# Altium schematic sheet MAIN.SchDoc
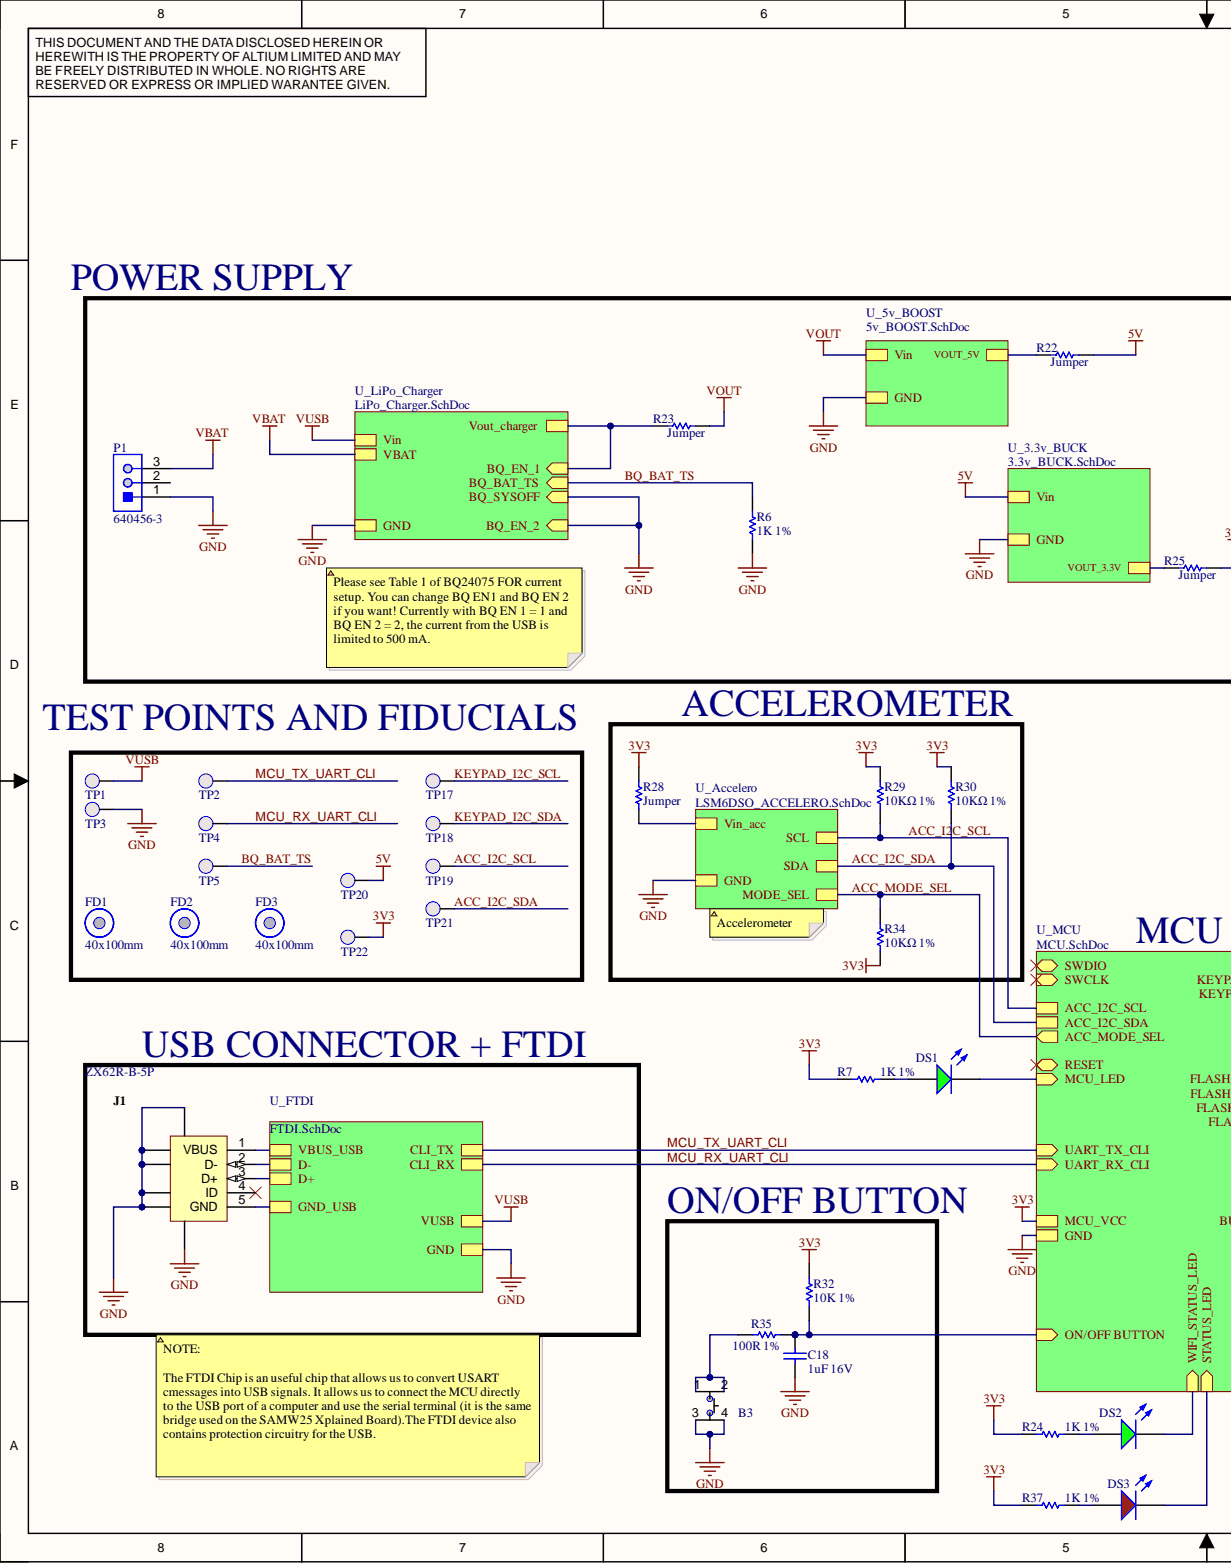
[diagram p0: MAIN.SchDoc, left]
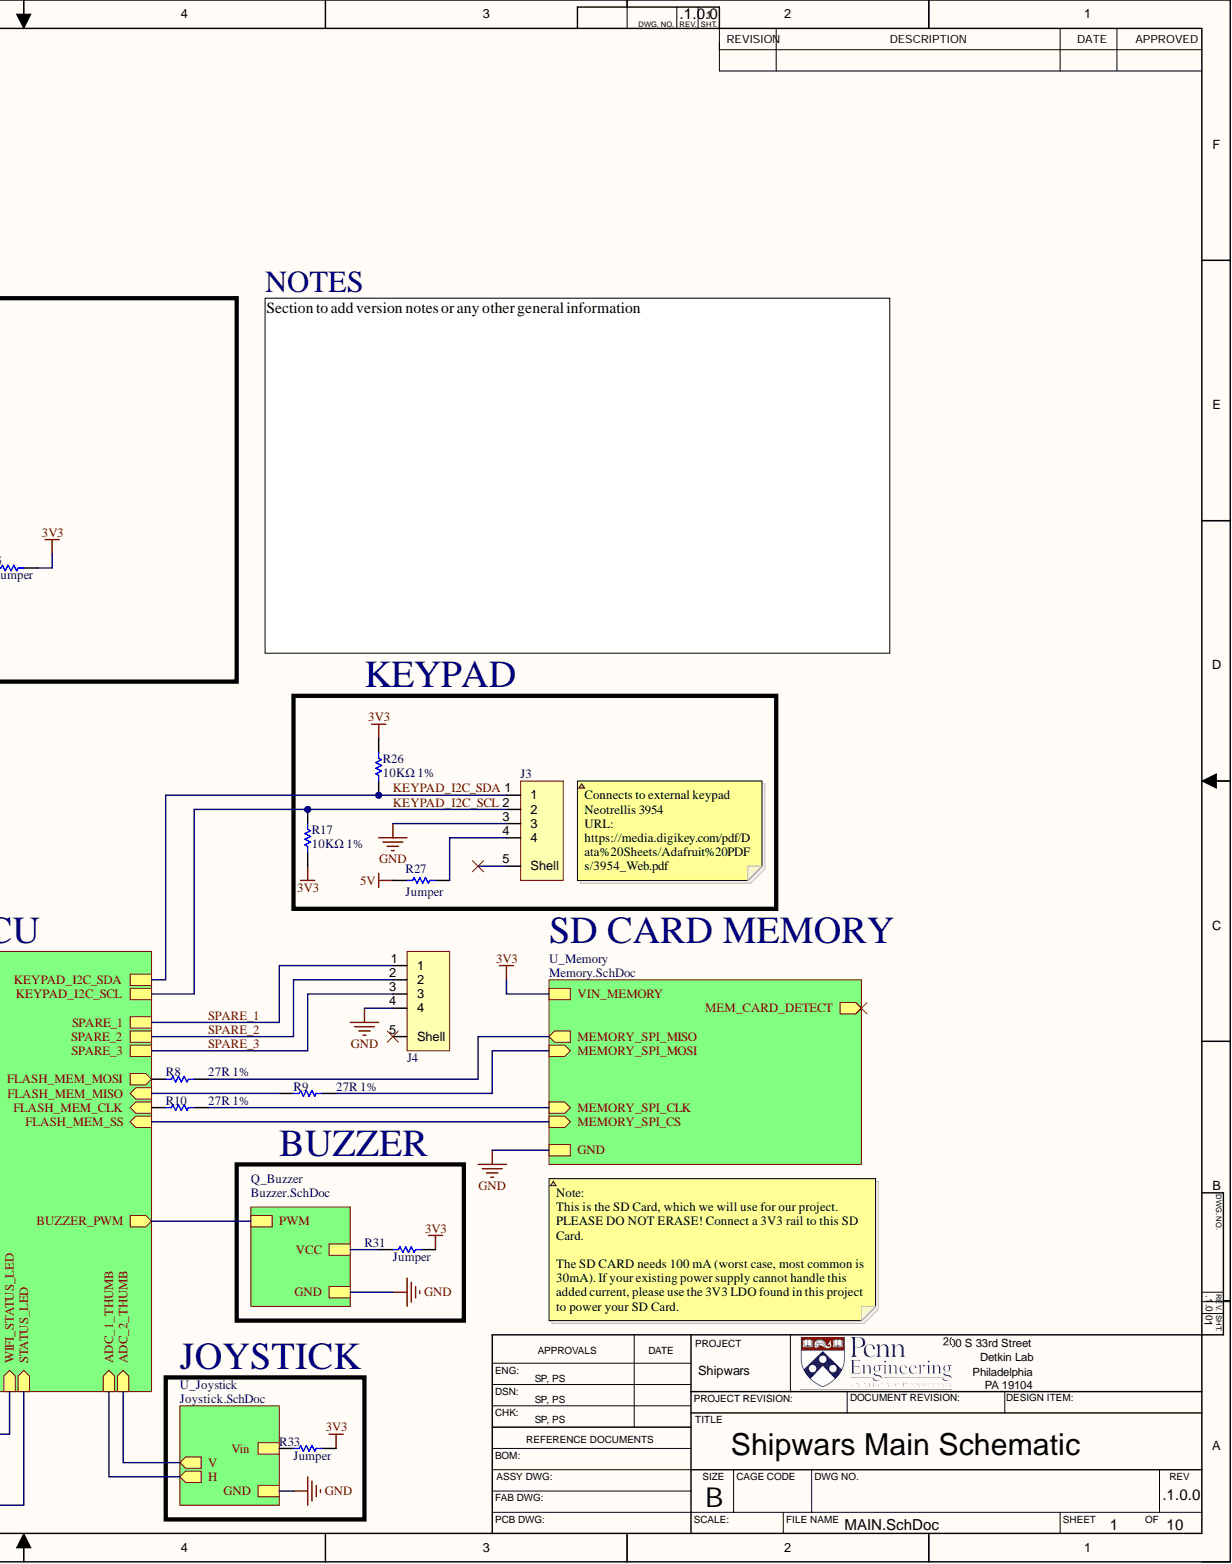
[diagram p1: MAIN.SchDoc, right]

## Parts
B3  PTS810 SJK 250 SMTR LFS  [PTS810 SJK 250 SMTR LFS]  (value not drawn)
C18  1uF 16V  value 1uF  [CAPC0603(1608)100_N]
DS1  LTST-C170GKT  [LTST-C170GKT_V]  (value not drawn)
DS2  LTST-C170GKT  [LTST-C170GKT_V]  (value not drawn)
DS3  LTST-C170CKT  [LTST-C170CKT_V]  (value not drawn)
FD1  40x100mm  [Fiducial_FT]  (no BOM)
FD2  40x100mm  [Fiducial_FT]  (no BOM)
FD3  40x100mm  [Fiducial_FT]  (no BOM)
J1  ZX62R-B-5P  [HIRO-ZX62R-B-5P_V]
J3  S4B-PH-SM4-TB(LF)(SN)  [S4B-PH-SM4-TB(LF)(SN)]  (value not drawn)
J4  S4B-PH-SM4-TB(LF)(SN)  [S4B-PH-SM4-TB(LF)(SN)]  (value not drawn)
P1  640456-3  [TECO-640456-3]
R6  1K 1%  [RESC0603(1608)_N]
R7  1K 1%  [RESC0603(1608)_N]
R8  27R 1%  [RESC0603(1608)_N]
R9  27R 1%  [RESC0603(1608)_N]
R10  27R 1%  [RESC0603(1608)_N]
R17  10KΩ 1%  [RESC0603(1608)_N]
R22  Jumper  [RESC0603(1608)_N]
R23  Jumper  [RESC0603(1608)_N]
R24  1K 1%  [RESC0603(1608)_N]
R25  Jumper  [RESC0603(1608)_N]
R26  10KΩ 1%  [RESC0603(1608)_N]
R27  Jumper  [RESC0603(1608)_N]
R28  Jumper  [RESC0603(1608)_N]
R29  10KΩ 1%  [RESC0603(1608)_N]
R30  10KΩ 1%  [RESC0603(1608)_N]
R31  Jumper  [RESC0603(1608)_N]
R32  10K 1%  [RESC0603(1608)_N]
R33  Jumper  [RESC0603(1608)_N]
R34  10KΩ 1%  [RESC0603(1608)_N]
R35  100R 1%  [RESC0603(1608)_N]
R37  1K 1%  [RESC0603(1608)_N]
TP1  0.1" Pad  [PCBComponent]  (value not drawn)  (no BOM)
TP2  0.1" Pad  [PCBComponent]  (value not drawn)  (no BOM)
TP3  0.1" Pad  [PCBComponent]  (value not drawn)  (no BOM)
TP4  0.1" Pad  [PCBComponent]  (value not drawn)  (no BOM)
TP5  0.1" Pad  [PCBComponent]  (value not drawn)  (no BOM)
TP17  0.1" Pad  [PCBComponent]  (value not drawn)  (no BOM)
TP18  0.1" Pad  [PCBComponent]  (value not drawn)  (no BOM)
TP19  0.1" Pad  [PCBComponent]  (value not drawn)  (no BOM)
TP20  0.1" Pad  [PCBComponent]  (value not drawn)  (no BOM)
TP21  0.1" Pad  [PCBComponent]  (value not drawn)  (no BOM)
TP22  0.1" Pad  [PCBComponent]  (value not drawn)  (no BOM)

## Nets
3V3: R7.1 R17.2 R24.1 R25.2 R26.2 R28.2 R29.2 R30.2 R31.2 R32.2 R33.2 R34.1 R37.1 TP22.1
5V: R22.2 R27.2 TP20.1
GND: B3.3 B3.4 C18.1 J1.6 J1.7 J1.8 J1.9 J1.10 J1.11 J3.3 J4.4 P1.1 R6.2 TP3.1
VBAT: P1.3
VOUT: R23.2
VUSB: TP1.1
ACC_I2C_SCL: R29.1 TP19.1
ACC_I2C_SDA: R30.1 TP21.1
ACC_MODE_SEL: R34.2
BQ_BAT_TS: R6.1 TP5.1
KEYPAD_I2C_SCL: J3.2 R17.1 TP17.1
KEYPAD_I2C_SDA: J3.1 R26.1 TP18.1
MCU_RX_UART_CLI: TP4.1
MCU_TX_UART_CLI: TP2.1
SPARE_1: J4.1
SPARE_2: J4.2
SPARE_3: J4.3
NetB3_1: B3.1 B3.2 R35.1
NetC18_2: C18.2 R32.1 R35.2
NetDS1_1: DS1.1 R7.2
NetDS1_2: DS1.2
NetDS2_1: DS2.1 R24.2
NetDS2_2: DS2.2
NetDS3_1: DS3.1 R37.2
NetDS3_2: DS3.2
NetJ1_1: J1.1(VBUS)
NetJ1_2: J1.2(D-)
NetJ1_3: J1.3(D+)
NetJ1_4: J1.4(ID)
NetJ1_5: J1.5(GND)
NetJ3_4: J3.4 R27.1
NetJ3_5: J3.5(Shell)
NetJ4_5: J4.5(Shell)
NetP1_2: P1.2
NetR8_1: R8.1
NetR8_2: R8.2
NetR9_1: R9.1
NetR9_2: R9.2
NetR10_1: R10.1
NetR10_2: R10.2
NetR22_1: R22.1
NetR23_1: R23.1
NetR25_1: R25.1
NetR28_1: R28.1
NetR31_1: R31.1
NetR33_1: R33.1
N00001:
N00002:
N00003:
N00004:
N00005:
N00006:
N00007:
N00008:

## Library data of the parts
B3 = PTS810 SJK 250 SMTR LFS: SWITCH TACTILE SPST-NO 0.05A 16V Tactile Switch SPST-NO Top Actuated Surface Mount; Manufacturer: ITT /  C&K Components; Part # Aliases: Y97LT25B5DBFP; Actuator: Oval; Brand: C&K Components; Contact Form: SPST; Current Rating: 50 mA; Mounting Direction: Straight; Operating Force: 2.6 N; Stem Height: 2.5 mm; Switch Function: OFF - (ON); Travel: 0.15 mm; Voltage Rating DC: 16 VDC; Color: White; Component Kind: Standard; ComponentLink1Description: https://datasheet.ciiva.com/18514/pts810-4mar13-18514061.pdf; ComponentLink1URL: https://datasheet.ciiva.com/18514/pts810-4mar13-18514061.pdf; ComponentLink2Description: https://datasheet.ciiva.com/18514/pts810-4mar13-18514062.pdf; ComponentLink2URL: https://datasheet.ciiva.com/18514/pts810-4mar13-18514062.pdf; ComponentLink3Description: https://datasheet.ciiva.com/18514/pts810-4mar13-18514063.pdf; ComponentLink3URL: https://datasheet.ciiva.com/18514/pts810-4mar13-18514063.pdf; Contact Plating: Silver; Factory Pack Quantity: 2900; Library Name: Schematics.SchLib; Manufacturer Part Number: PTS810SJK250SMTRLFS; Mounting Style: SMD/SMT; Operating Temperature Range: - 40 C to + 85 C; Packaging: Reel; Product Category: Tactile Switches; RoHS: Details; Series: PTS810; Supplier 1: Digi-Key; Supplier Part Number 1: CKN10503CT-ND; Termination Style: J Form Lead
C18 = CMP-1035-02736-1: CAP 1uF 16V ±5% 0603 (1608 Metric) Thickness 1mm SMD; Case-EIA: 0603; Case-Metric: 1608; Tolerance: Â±5%; Component Kind: Standard; Library Name: Altium Content Vault; Max Thickness: 1 mm; Rated Voltage: 16 V; Technology: SMT
DS1 DS2 = CMP-1674-00002-5: LED Uni-Color Green, 100 mW, 120 mA, -55 to 85 degC, 2-Pin SMD, RoHS, Tape and Reel; Manufacturer: Lite-On; Colour: Green; Component Kind: Standard; ComponentLink1Description: Manufacturer URL; ComponentLink1URL: http://www.us.liteon.com/; ComponentLink2Description: Datasheet; ComponentLink2URL: http://media.digikey.com/pdf/Data%20Sheets/Lite-On%20PDFs/LTST-C170GKT.pdf; DatasheetVersion: 03/2003; Height: 1.1 mm; Length: 2 mm; Library Name: Altium Content Vault; Max Operating Temperature: 85 degC; Min Operating Temperature: -55 degC; Mount: Surface Mount; Mounting Technology: Surface Mount; Package Quantity: 1; PackageDescription: 2-Pin Surface Mount Device, Body 2 x 1.25 mm; PackageReference: C170GKT; Packaging: Tape and Reel; Pins: 2; RoHSCompliant: true
DS3 = CMP-1674-00001-5: LED Uni-Color Red, 100 mW, 200 mA, -55 to 85 degC, 2-Pin SMD, RoHS, Tape and Reel; Manufacturer: Lite-On; Colour: Red; Component Kind: Standard; ComponentLink1Description: Manufacturer URL; ComponentLink1URL: http://www.us.liteon.com/; ComponentLink2Description: Datasheet; ComponentLink2URL: http://media.digikey.com/pdf/Data%20Sheets/Lite-On%20PDFs/LTST-C170CKT.pdf; DatasheetVersion: 03/2003; Height: 1.1 mm; Length: 2 mm; Library Name: Altium Content Vault; Max Operating Temperature: 85 degC; Min Operating Temperature: -55 degC; Mount: Surface Mount; Mounting Technology: Surface Mount; Package Quantity: 3000; PackageDescription: 2-Pin Surface Mount Device, Body 2 x 1.25 mm; PackageReference: C170CKT; Packaging: Tape and Reel; Pins: 2; RoHSCompliant: true
FD1-FD3 = CMP-00174-A.4: Fiducial 40x100mm; Library Name: Components - 01.02.21 - 21.11.SchLib
J1 = CMP-0031-00001-1: Connector; USBMicro-B,Reverse type, SMT; 5 Position; Right Angle; Component Kind: Standard; ComponentLink1Description: Manufacturer URL; ComponentLink1URL: http://www.hirose.com/â€Ž; ComponentLink2Description: Datasheet; ComponentLink2URL: http://www.hirose.co.jp/cataloge_hp/e24200011.pdf; DatasheetVersion: 08/2010; Library Name: Altium Content Vault; Mounting Technology: Surface Mount; Operating Temperature: -30 to 85 degC; PackageDescription: 5-Pin USB Micro-B R/A Connector; PackageReference: ZX62R-B-5P; PartNumber: ZX62R-B-5P
J3 J4 = S4B-PH-SM4-TB(LF)(SN): JST (JAPAN SOLDERLESS TERMINALS) - S4B-PH-SM4-TB(LF)(SN) - Wire-To-Board Connector, 2 mm, 4 Contacts, Header, PH Series, Surface Mount, 1 Rows; Connector Type: Header,Male Pin; Contact Resistance: 20mR; Current Rating: 2A; Gender: Male; Insulation Resistance: 1GR; Max Voltage Rating (AC): 100V; Number of Contacts: 4; Pitch: 2mm; Category: Connectors; Color: Natural,White; Component Kind: Standard; ComponentLink1Description: https://datasheet.ciiva.com/11746/0900766b80df0d9d-11746552.pdf?src-supplier=RSComponents; ComponentLink1URL: https://datasheet.ciiva.com/11746/0900766b80df0d9d-11746552.pdf?src-supplier=RSComponents; ComponentLink2Description: https://datasheet.ciiva.com/712/eph-712578.pdf?src-supplier=Digi-Key; ComponentLink2URL: https://datasheet.ciiva.com/712/eph-712578.pdf?src-supplier=Digi-Key; ComponentLink3Description: https://datasheet.ciiva.com/712/eph-712579.pdf?src-supplier=Digi-Key; ComponentLink3URL: https://datasheet.ciiva.com/712/eph-712579.pdf?src-supplier=Digi-Key; ComponentLink4Description: https://datasheet.ciiva.com/730/eph-730675.pdf?src-supplier=Digi-Key; ComponentLink4URL: https://datasheet.ciiva.com/730/eph-730675.pdf?src-supplier=Digi-Key; ComponentLink5Description: https://datasheet.ciiva.com/8052/1699381-8052234.pdf?src-supplier=Element14; ComponentLink5URL: https://datasheet.ciiva.com/8052/1699381-8052234.pdf?src-supplier=Element14; ComponentLink6Description: https://datasheet.ciiva.com/26894/getdatasheetpartid-639990-26894620.pdf?src-supplier=Verical; ComponentLink6URL: https://datasheet.ciiva.com/26894/getdatasheetpartid-639990-26894620.pdf?src-supplier=Verical; ComponentLink7Description: https://datasheet.ciiva.com/16376/eph-16376783.pdf?src-supplier=Future+Electronics; ComponentLink7URL: https://datasheet.ciiva.com/16376/eph-16376783.pdf?src-supplier=Future+Electronics; Contact Material: Copper Alloy,Tin,Bronze,Brass; Contact Mating Length: 0.13inch; Contact Plating: Tin,Copper; Contact Shape: Square; Depth: 7.6mm; Fastening Type: Detent; Flammability Rating: UL94 V-0; Height: 9.4mm; Housing Color: Beige; Housing Material: Polyamide; Insulation Color: Natural; Insulation Material: Nylon; Lead Free: Lead Free; Lead Pitch: 2mm; Length: 11.9mm; Library Name: S4B-PH-SM4-TB_LF_SN.SchLib; Manufacturer: JST; Manufacturer Part Number: S4B-PH-SM4-TB(LF)(SN); Max Current Rating: 2A; Max Operating Temperature: 85°C; Max Voltage Rating (DC): 100V; Min Operating Temperature: -25°C; Mount: Surface Mount; Number of Poles: 4; Number of Positions: 4; Number of Rows: 1; Orientation: Right Angle; Packaging: Tape and Reel; REACH SVHC: No SVHC; RoHS: Yes; Supplier 1: Newark; Supplier Part Number 1: 38K8075; Termination: Solder; Voltage Rating: 100V; Voltage Rating (AC): 100V; Width: 5mm; Working Voltage: 100V
P1 = CMP-2000-05418-1: Male Header, Pitch 2.54 mm, 1 x 3 Position, Height 10.03 mm, Tail Length 3.56 mm, -55 to 105 degC; Manufacturer: TE Connectivity; Agency Approvals: CSA,UL; Angle: Straight; Brand/Series: MTA-100Series; Color, Housing: White; Connector Type: MTA-100; Contact Centerline Spacing: 0.100    in.; Contact Termination Area Plating: Tin; Current, Rating: 5    A; Material, Contact: CopperAlloy; Material, Housing: Polyester; Mount Angle: Vertical; Mounting Hole Size: 0.04    "; Number of Contacts: 3; Pin Spacing: 0.1    "; Primary Type: InterconnectSystem; Special Features: Pinstrip; Standards: RoHSCompliant,ELVCompliant,UL94V-0; Temperature, Operating: -55to+105    degC; Voltage, Rating: 250    V; Color: White; Component Kind: Standard; Contact Mating Area Plating: Tin; Contact Plating: Tin; Contact Shape: Square; Datasheet URL: https://datasheet.ciiva.com/16456/eng-ds-82056-mta-0608-16456886.pdf; Datasheet Version: Jun-08; Flammability Rating: UL94V-0; Length, Overall: 0.3    "; Length, Pin: 0.14    "; Length, Terminal Post: 0.14    in.; Library Name: Altium Content Vault; Manufacturer URL: http://www.te.com/; Mounting Type: PCMount; Number of Positions: 3; Number of Rows: 1; Size, Post: 0.025    in.; Termination: Solder; Type: PCBPlug
R6 R7 R24 R37 = CMP-1012-00510-1: 1K 0.1W 1% 0603 (1608 Metric)  SMD; Case-EIA: 0603; Case-Metric: 1608; Value: 1000.00 Ohm; Component Kind: Standard; Library Name: Altium Content Vault; Power: 0.1 W; Technology: SMT; Tolerance: 1 %
R8-R10 = CMP-1012-00331-1: 27R 0.1W 1% 0603 (1608 Metric)  SMD; Case-EIA: 0603; Case-Metric: 1608; Value: 27.00 Ohm; Component Kind: Standard; Library Name: Altium Content Vault; Power: 0.1 W; Technology: SMT; Tolerance: 1 %
R17 R26 R29 R30 R32 R34 = CMP-1012-00623-1: 10K 0.1W 1% 0603 (1608 Metric)  SMD; Case-EIA: 0603; Case-Metric: 1608; Value: 10000.00 Ohm; Component Kind: Standard; Library Name: Altium Content Vault; Power: 0.1 W; Technology: SMT; Tolerance: 1 %
R22 R23 R25 R27 R28 R31 R33 = CMP-1012-00001-1: Jumper 0603 (1608 Metric); Case-EIA: 0603; Case-Metric: 1608; Power: None; Value: 0.00 Ohm; Component Kind: Standard; Library Name: Altium Content Vault; Technology: SMT; Tolerance: None
R35 = CMP-1012-00397-1: 100R 0.1W 1% 0603 (1608 Metric)  SMD; Case-EIA: 0603; Case-Metric: 1608; Value: 100.00 Ohm; Component Kind: Standard; Library Name: Altium Content Vault; Power: 0.1 W; Technology: SMT; Tolerance: 1 %
TP1-TP5 TP17-TP22 = 0.1" Pad-A.4: Description: 0.1" Pad; Library Name: Components - 12.02.20 - 18.05.SchLib
